# Altium schematic sheet COFFEE_MAIN.SchDoc
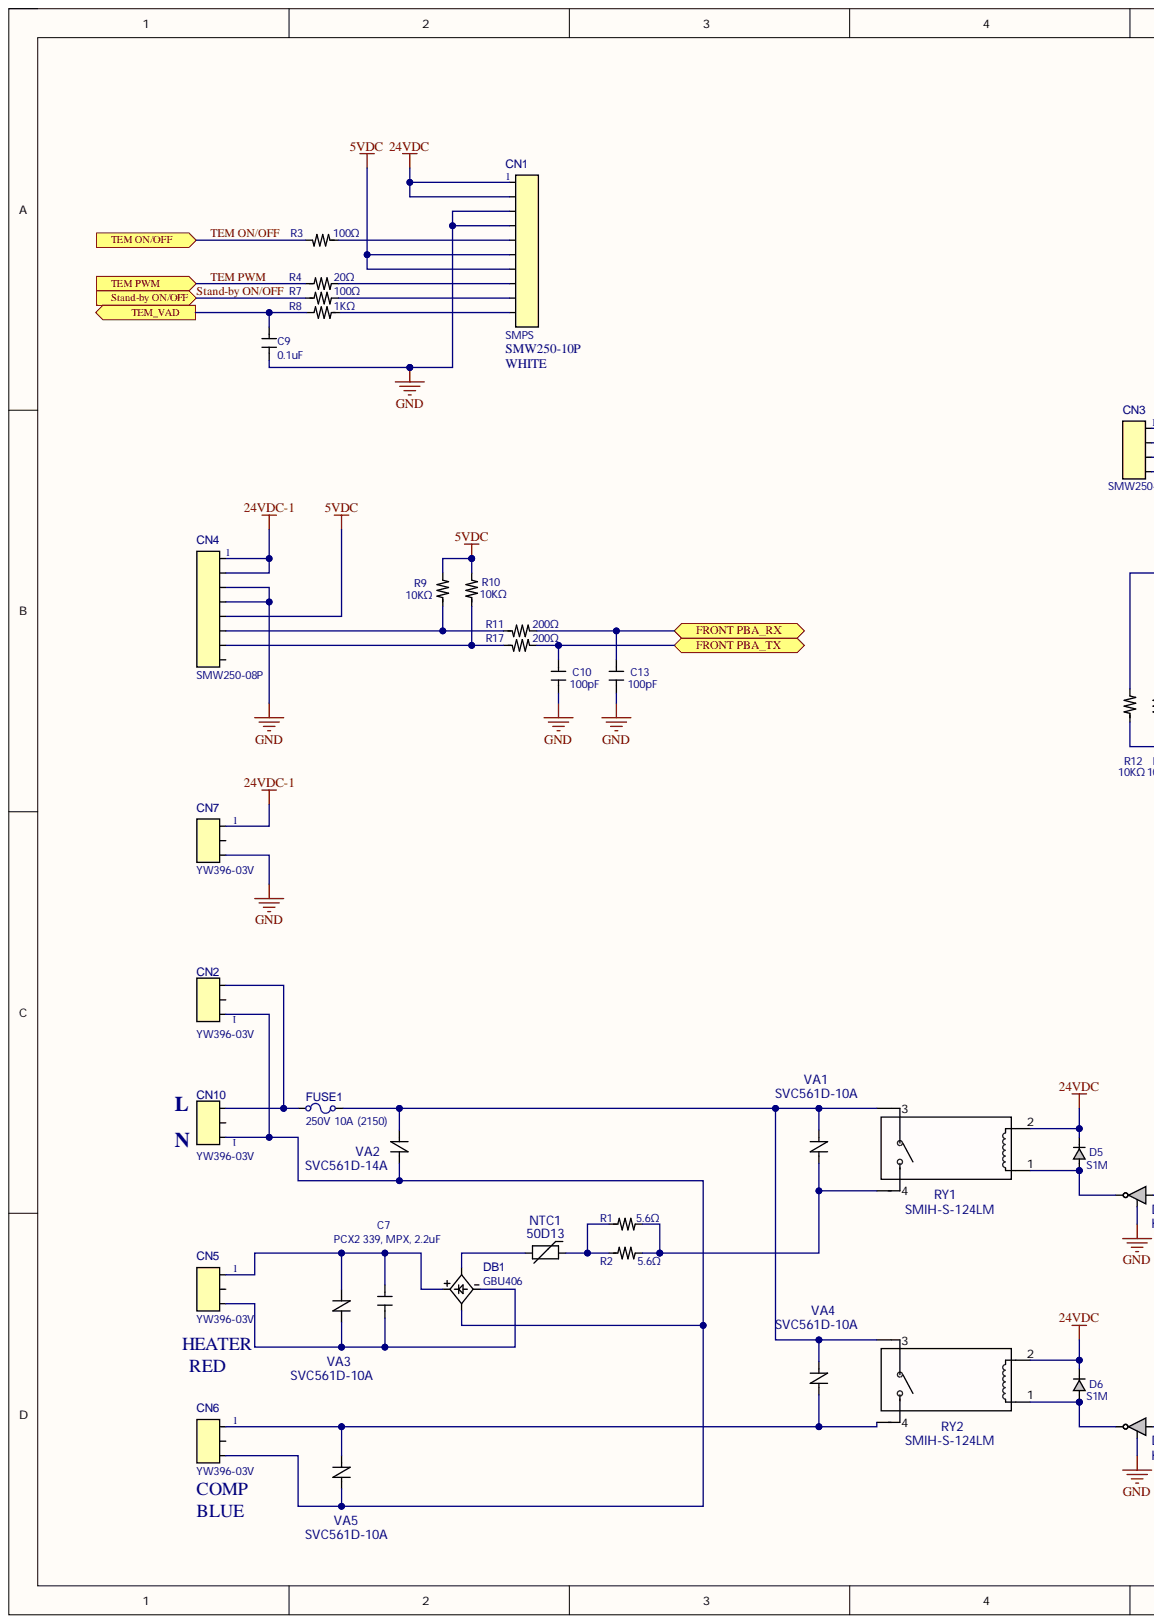
[diagram p0: COFFEE_MAIN.SchDoc, left]
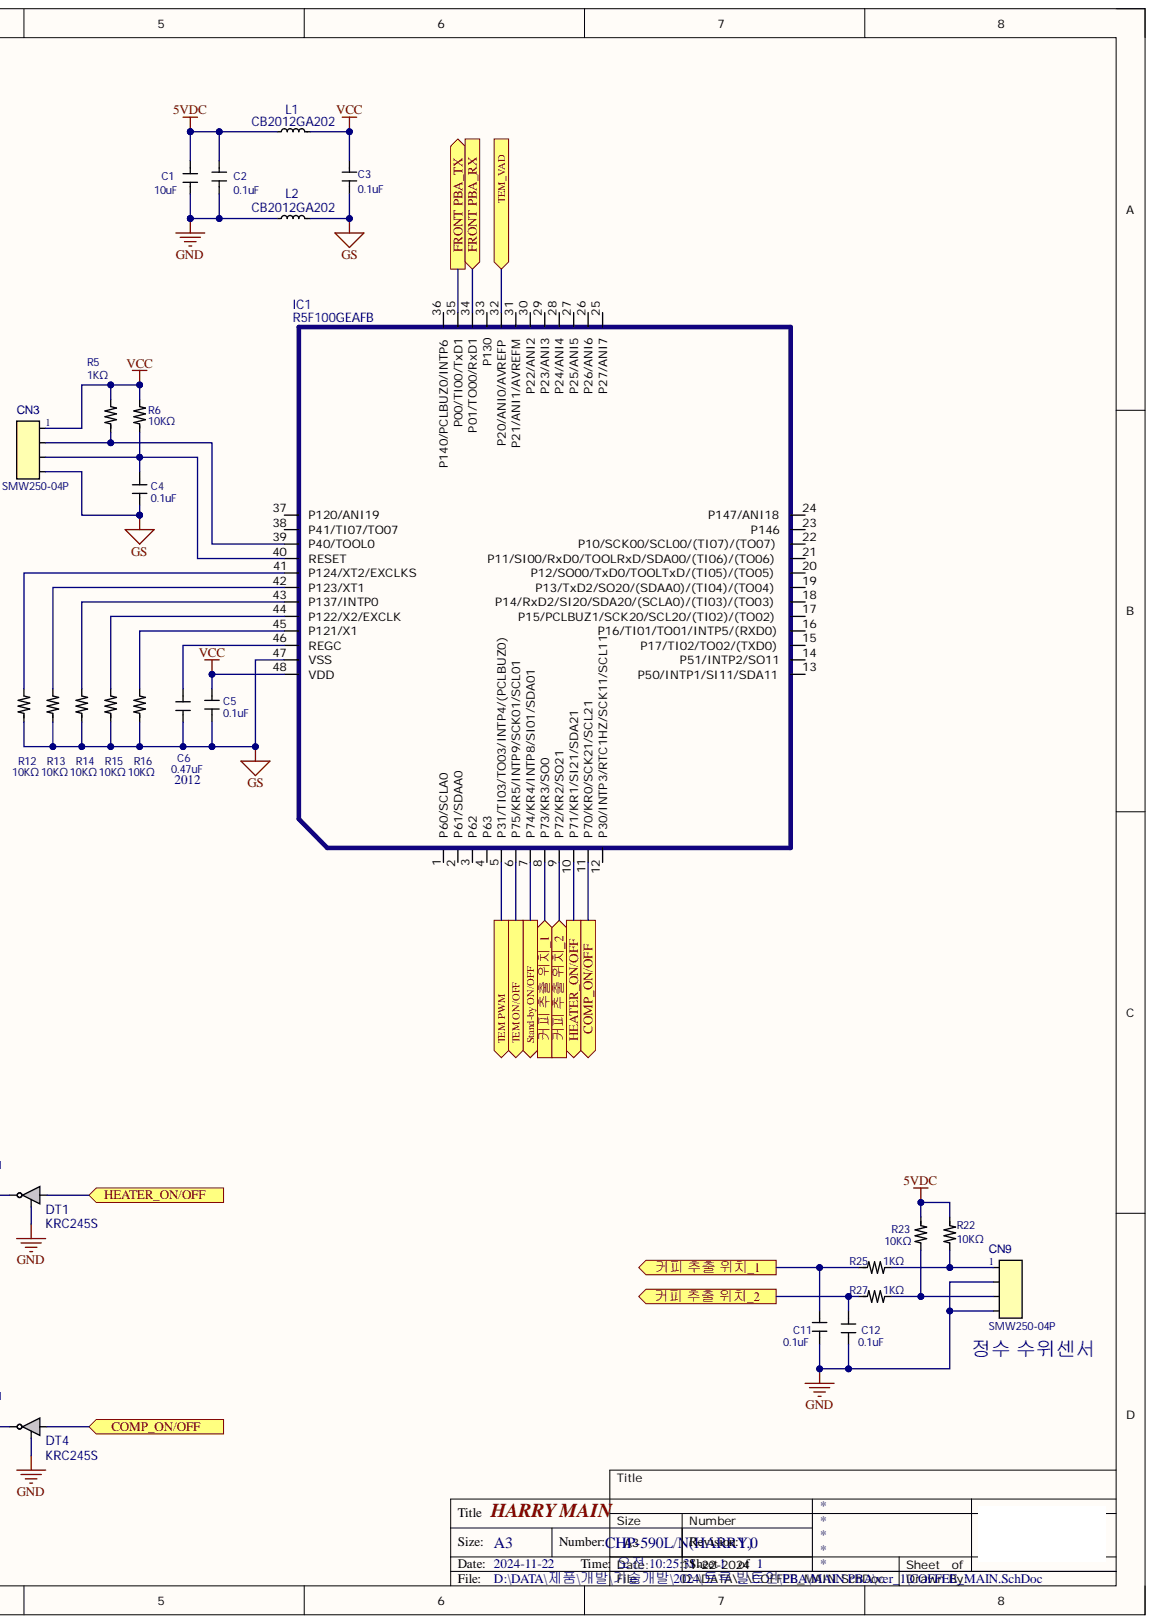
[diagram p1: COFFEE_MAIN.SchDoc, right]

## Parts
C1  10uF  [C-SMD-3216]
C2  0.1uF  [C-SMD-1608]
C3  0.1uF  [C-SMD-1608]
C4  0.1uF  [C-SMD-1608]
C5  0.1uF  [C-SMD-1608]
C6  0.47uF  [C-SMD-2012]
C7  PCX2 339, MPX, 2.2uF  [C-BOX-27_0P-312818]
C9  0.1uF  [C-SMD-1608]
C10  100pF  [C-SMD-1608]
C11  0.1uF  [C-SMD-1608]
C12  0.1uF  [C-SMD-1608]
C13  100pF  [C-SMD-1608]
CN1  SMPS  14.Color: WHITE  21.Value: SMW250-10P  [CN-SMW250-10-10P-2_5P-274058-070H]
CN2  YW396-03V  [CN-YW396-03V-03P-3_96P-118081-111H]
CN3  SMW250-04P  [CN-SMW250-04-04P-2_5P-124058-070H]
CN4  SMW250-08P  [CN-SMW250-08-08P-2_5P-224058-070H]
CN5  YW396-03V  [CN-YW396-03V-03P-3_96P-118081-111H]
CN6  YW396-03V  [CN-YW396-03V-03P-3_96P-118081-111H]
CN7  YW396-03V  [CN-YW396-03V-03P-3_96P-118081-111H]
CN9  SMW250-04P  [CN-SMW250-04-04P-2_5P-124058-070H]
CN10  YW396-03V  [CN-YW396-03V-03P-3_96P-118081-111H]
D5  S1M  [D_DO-214AC]
D6  S1M  [D_DO-214AC]
DB1  GBU406  [GBU406-Footprint-1]
DT1  KRC245S  [TR-SOT-23_BCE-3P-1_0P-031027-013H]
DT4  KRC245S  [TR-SOT-23_BCE-3P-1_0P-031027-013H]
FUSE1  250V 10A (2150)  [FUSE_20MM]
IC1  R5F100GEAFB  [IC-LQFP48P-0_48P]
L1  CB2012GA202  [L_2012SMD]
L2  CB2012GA202  [L_2012SMD]
NTC1  50D13  [TH_11PI_7.5P]
R1  5.6Ω  [R-AX-15_0P-120056-56H]
R2  5.6Ω  [R-AX-15_0P-120056-56H]
R3  100Ω  [R-SMD-1608-045H]
R4  20Ω  [R-SMD-1608-045H]
R5  1KΩ  [R-SMD-1608-045H]
R6  10KΩ  [R-SMD-1608-045H]
R7  100Ω  [R-SMD-1608-045H]
R8  1KΩ  [R-SMD-1608-045H]
R9  10KΩ  [R-SMD-1608-045H]
R10  10KΩ  [R-SMD-1608-045H]
R11  200Ω  [R-SMD-1608-045H]
R12  10KΩ  [R-SMD-1608-045H]
R13  10KΩ  [R-SMD-1608-045H]
R14  10KΩ  [R-SMD-1608-045H]
R15  10KΩ  [R-SMD-1608-045H]
R16  10KΩ  [R-SMD-1608-045H]
R17  200Ω  [R-SMD-1608-045H]
R22  10KΩ  [R-SMD-1608-045H]
R23  10KΩ  [R-SMD-1608-045H]
R25  1KΩ  [R-SMD-1608-045H]
R27  1KΩ  [R-SMD-1608-045H]
RY1  SMIH-S-124LM  [OMIH-SS-124LM]
RY2  SMIH-S-124LM  [OMIH-SS-124LM]
VA1  SVC561D-10A  [ZNR_D10]
VA2  SVC561D-14A  [ZNR_D14]
VA3  SVC561D-10A  [ZNR_D10]
VA4  SVC561D-10A  [ZNR_D10]
VA5  SVC561D-10A  [ZNR_D10]

## Ports
COMP_ON/OFF, FRONT PBA_RX, FRONT PBA_TX, HEATER_ON/OFF, Stand-by ON/OFF, TEM ON/OFF, TEM PWM, TEM_VAD, 커피 추출 위치_1, 커피 추출 위치_2

## Nets
5VDC: C1.2 C2.1 CN1.6 CN1.7 CN4.5 L1.1 R9.2 R10.2 R22.1 R23.1
24VDC: CN1.1 CN1.2 D5.C D6.C RY1.2 RY2.2
24VDC-1: CN4.1 CN4.2 CN7.1
GND: C1.1 C2.2 C9.2 C10.2 C11.2 C12.1 C13.2 CN1.3 CN1.4 CN4.3 CN4.4 CN7.3 CN9.2 CN9.4 DT1.E DT4.E L2.1
GS: C3.2 C4.1 C5.2 C6.1 CN3.4 IC1.47(VSS) L2.2 R12.1 R13.1 R14.1 R15.1 R16.1
VCC: C3.1 C5.1 CN3.1 IC1.48(VDD) L1.2 R5.2 R6.2
COMP_ON/OFF: DT4.B IC1.11(P70/KR0/SCK21/SCL21) ; port COMP_ON/OFF
FRONT PBA_RX: C13.1 IC1.34(P01/TO00/RxD1) R11.2 ; port FRONT PBA_RX
FRONT PBA_TX: C10.1 IC1.35(P00/TI00/TxD1) R17.2 ; port FRONT PBA_TX
HEATER_ON/OFF: DT1.B IC1.10(P71/KR1/SI21/SDA21) ; port HEATER_ON/OFF
Stand-by ON/OFF: IC1.7(P74/KR4/INTP8/SI01/SDA01) R7.1 ; port Stand-by ON/OFF
TEM ON/OFF: IC1.6(P75/KR5/INTP9/SCK01/SCL01) R3.2 ; port TEM ON/OFF
TEM PWM: IC1.5(P31/TI03/TO03/INTP4/(PCLBUZ0)) R4.1 ; port TEM PWM
TEM_VAD: C9.1 IC1.32(P20/ANI0/AVREFP) R8.2 ; port TEM_VAD
커피 추출 위치_1: C11.1 IC1.8(P73/KR3/SO0) R25.1 ; port 커피 추출 위치_1
커피 추출 위치_2: C12.2 IC1.9(P72/KR2/SO21) R27.1 ; port 커피 추출 위치_2
NetC4_2: C4.2 CN3.3 IC1.40(RESET) R6.1
NetC6_2: C6.2 IC1.46(REGC)
NetC7_1: C7.1 CN5.1 DB1.1 VA3.2
NetC7_2: C7.2 CN5.3 DB1.4 VA3.1
NetCN1_5: CN1.5 R3.1
NetCN1_8: CN1.8 R4.2
NetCN1_9: CN1.9 R7.2
NetCN1_10: CN1.10 R8.1
NetCN2_1: CN2.1 CN6.3 CN10.1 DB1.3 VA2.2 VA5.1
NetCN2_3: CN2.3 CN10.3 FUSE1.1
NetCN3_2: CN3.2 IC1.39(P40/TOOL0) R5.1
NetCN4_6: CN4.6 R9.1 R11.1
NetCN4_7: CN4.7 R10.1 R17.1
NetCN6_1: CN6.1 RY2.4 VA4.1 VA5.2
NetCN9_1: CN9.1 R22.2 R25.2
NetCN9_3: CN9.3 R23.2 R27.2
NetD5_A: D5.A DT1.C RY1.1
NetD6_A: D6.A DT4.C RY2.1
NetDB1_2: DB1.2 NTC1.1
NetFUSE1_2: FUSE1.2 RY1.3 RY2.3 VA1.2 VA2.1 VA4.2
NetIC1_41: IC1.41(P124/XT2/EXCLKS) R12.2
NetIC1_42: IC1.42(P123/XT1) R13.2
NetIC1_43: IC1.43(P137/INTP0) R14.2
NetIC1_44: IC1.44(P122/X2/EXCLK) R15.2
NetIC1_45: IC1.45(P121/X1) R16.2
NetNTC1_2: NTC1.2 R1.1 R2.1
NetR1_2: R1.2 R2.2 RY1.4 VA1.1

Not connected: CN2 2; CN4 8; CN5 2; CN6 2; CN7 2; CN10 2; IC1 1(P60/SCLA0) 2(P61/SDAA0) 3(P62) 4(P63) 12(P30/INTP3/RTC1HZ/SCK11/SCL11) 13(P50/INTP1/SI11/SDA11) 14(P51/INTP2/SO11) 15(P17/TI02/TO02/(TXD0)) 16(P16/TI01/TO01/INTP5/(RXD0)) 17(P15/PCLBUZ1/SCK20/SCL20/(TI02)/(TO02)) 18(P14/RxD2/SI20/SDA20/(SCLA0)/(TI03)/(TO03)) 19(P13/TxD2/SO20/(SDAA0)/(TI04)/(TO04)) 20(P12/SO00/TxD0/TOOLTxD/(TI05)/(TO05)) 21(P11/SI00/RxD0/TOOLRxD/SDA00/(TI06)/(TO06)) 22(P10/SCK00/SCL00/(TI07)/(TO07)) 23(P146) 24(P147/ANI18) 25(P27/ANI7) 26(P26/ANI6) 27(P25/ANI5) 28(P24/ANI4) 29(P23/ANI3) 30(P22/ANI2) 31(P21/ANI1/AVREFM) 33(P130) 36(P140/PCLBUZ0/INTP6) 37(P120/ANI19) 38(P41/TI07/TO07)

## Library data of the parts
C1 = CMP-00585-2: 10uF,25V,3216,125℃,+10, -10%,,Reel,; 02.Part Number: 8026573; 01.No: 26432; 03.부품분류: CAPACITOR, MLCC; 13.PKG: 3216; 15.C Tolerance: 10; 11.Capacitance: 10uF; 12.Voltage: 25V; 14.Temperature: 125℃; 16.Pitch: -10%; 21.Value: 10uF; 22.등록일: 2014-02-28; Component Kind: Standard; Library Name: Coway 365
C2 C3 C5 C9 = CMP-00596-2: 0.1uF,50V,1608,125℃,+10, -10%,,Reel,; 02.Part Number: 8026584; 01.No: 26443; 03.부품분류: CAPACITOR, MLCC; 13.PKG: 1608; 15.C Tolerance: 10; 11.Capacitance: 0.1uF; 12.Voltage: 50V; 14.Temperature: 125℃; 16.Pitch: -10%; 21.Value: 0.1uF; 22.등록일: 2014-02-28; Component Kind: Standard; Library Name: <Coway> Concord
C4 C11 C12 = CMP-00566-2: 0.1uF,50V,1608,125℃,+10, -10%,,Reel,; 02.Part Number: 8026554; 01.No: 26413; 03.부품분류: CAPACITOR, MLCC; 13.PKG: 1608; 15.C Tolerance: 10; 11.Capacitance: 0.1uF; 12.Voltage: 50V; 14.Temperature: 125℃; 16.Pitch: -10%; 21.Value: 0.1uF; 22.등록일: 2014-02-28; Component Kind: Standard
  C4: Library Name: <Coway> Concord
  C11 C12: Library Name: Coway 365
C6 = CMP-00523-2: 0.47uF,50V,2012,125℃,+10,-10%,,Reel,; 02.Part Number: 8026191; 01.No: 26054; 03.부품분류: CAPACITOR, MLCC; 13.PKG: 2012; 15.C Tolerance: 10; 11.Capacitance: 0.47uF; 12.Voltage: 50V; 14.Temperature: 125℃; 16.Pitch: -10%; 21.Value: 0.47uF; 22.등록일: 2014-02-28; Component Kind: Standard; Library Name: <Coway> Concord
C7 = CMP-00647-2: 2.2uF,275V,31x28x18mm,100℃,,27.5mm,,; 02.Part Number: 8026223; 01.No: 58; 03.부품분류: CAPACITOR, FILM; 11.Capacitance: 2.2uF; 12.Voltage: 275V; 13.Dimension: 31x28x18mm; 14.Temperature: 100℃; 16.Pitch: 27.5mm; 21.Value: 2.2uF; 22.등록일: 2015-07-28; Component Kind: Standard; Library Name: Coway 365
C10 C13 = CMP-00577-2: 100pF,50V,1608,125℃,+5, -5%,,Reel,; 02.Part Number: 8026565; 01.No: 26424; 03.부품분류: CAPACITOR, MLCC; 13.PKG: 1608; 15.C Tolerance: 5; 11.Capacitance: 100pF; 12.Voltage: 50V; 14.Temperature: 125℃; 16.Pitch: -5%; 21.Value: 100pF; 22.등록일: 2014-02-28; Component Kind: Standard; Library Name: <Coway> Concord
CN1 = CMP-01012-2: straight,10,2.5,,250,3,; 02.Part Number: 8027203; 01.No: 26944; 03.부품분류: CONNECTOR, DIP; 12.No. of Pin: 10; 13.Pitch: 2.5; 15.I Max: 250; 16.Height: 3; 11Type: straight; 22.등록일: 2014-02-28; Component Kind: Standard; Library Name: <Coway> Concord
CN2 CN5-CN7 CN10 = CMP-00904-3: straight,3,3.96,,250,7.5,; 02.Part Number: 8027095; 01.No: 26836; 03.부품분류: CONNECTOR, DIP; 12.No. of Pin: 3; 13.Pitch: 3.96; 15.I Max: 250; 16.Height: 7.5; 11Type: straight; 14.Color: -; 21.Value: YW396-03V; 22.등록일: 2014-02-28; Component Kind: Standard; Library Name: Coway 365
CN3 CN9 = CMP-01006-3: straight,4,2.5,,250,3,; 02.Part Number: 8027197; 01.No: 26938; 03.부품분류: CONNECTOR, DIP; 12.No. of Pin: 4; 13.Pitch: 2.5; 15.I Max: 250; 16.Height: 3; 11Type: straight; 14.Color: -; 21.Value: SMW250-04P; 22.등록일: 2014-02-28; Component Kind: Standard; Library Name: Coway 365
CN4 = CMP-01010-2: straight,8,2.5,,250,3,; 02.Part Number: 8027201; 01.No: 26942; 03.부품분류: CONNECTOR, DIP; 12.No. of Pin: 8; 13.Pitch: 2.5; 15.I Max: 250; 16.Height: 3; 11Type: straight; 14.Color: -; 21.Value: SMW250-08P; 22.등록일: 2014-02-28; Component Kind: Standard; Library Name: Coway 365
D5 D6 = CMP-00066-2: 1000V,1A,1.1V,DO-214AC,,; 02.Part Number: 8025325; 01.No: 78; 03.부품분류: DIODE, RECTIFIER; 11.Vr: 1000V; 12.IF: 1A; 13.Power: 1.1V; 14.PKG: DO-214AC; 21.Value: S1M; 22.등록일: 2015-01-29; Component Kind: Standard; Library Name: <Coway> Concord
DB1 = CMP-00037-3: 800V,4A,1V,,,; 02.Part Number: 8025405; 01.No: 25557; 03.부품분류: DIODE, BRIDGE; 11.Vr: 800V; 12.IF: 4A; 13.No. of Pin: 1V; 14.Dimension: -; 21.Value: GBU406; 22.등록일: 2014-02-28; Component Kind: Standard; Library Name: Coway 365
DT1 DT4 = CMP-27504-1: NPN,50V,0.8A,SOT-23,0.2W,56,1K/10K,; 02.Part Number: 8025514; 01.No: 25664; 03.부품분류: TR, DIGITAL; 16.hfe: 56; 11.Channel: NPN; 12.Max VCE: 50V; 13.Max IC: 0.8A; 14.PKG: SOT-23; 15.Power: 0.2W; 17.R2/R1: 1K/10K; 21.Value: KRC245S; 22.등록일: 2014-02-28; Component Kind: Standard; Library Name: <Coway> Concord
FUSE1 = CMP-01936-2: 250,10,Time_Lag,,20±0.5,; 02.Part Number: 8027031; 01.No: 26796; 03.부품분류: PROTECTION DEVICE, FUSE; 11.Rated Voltage: 250; 12.Rated Current: 10; 13.MELTING TYPE: Time_Lag; 14.Meterial: -; 15.Dimension: 20±0.5; 21.Value: 250V 10A (2150); 22.등록일: 2014-02-28; Component Kind: Standard; Library Name: Coway 365
IC1 = CMP-00644-2: 48P,10P,44P,LFQFP,bit,bits,℃,; 02.Part Number: 8028492; 01.No: 5; 03.부품분류: IC, MICOM; 23.신뢰성: ok; 11.No. of Pin: 48P; 12.No. of ADC: 10P; 13.No. of IO PIN: 44P; 14.PKG: LFQFP; 15.ROM Size: bit; 16.RAM Size: bits; 17.t Max: ℃; 21.Value: R5F100GEAFB; 22.등록일: 2018-02-13; Component Kind: Standard; Library Name: <Coway> Concord
L1 L2 = CMP-01487-1: ,,,,,,; 02.Part Number: 8026661; 01.No: 26502; 03.부품분류: INDUCTOR, AXIAL; 11.Inductance: -; 12.Tolerance: -; 13.Current: -; 14.Dimension: -; 22.등록일: 2014-02-28; Component Kind: Standard; Library Name: <Coway> Concord
NTC1 = CMP-01434-2: 10,≤20,3000±5%,11,,7.5±1.0,; 02.Part Number: 8027081; 01.No: 148; 03.부품분류: PROTECTION DEVICE, THERMISTOR; 11.Resistance: 10; 14.PI: 11; 21.Value: 10D11; 12.Tolerance: ≤20; 13.B Value: 3000±5%; 16.Dimension: 7.5±1.0; 22.등록일: 2014-08-01; Component Kind: Standard; Library Name: Coway 365
R1 R2 = CMP-19775-2: 220KΩ,2W,F,11.0x4.0mm,,; 02.Part Number: 8022703; 01.No: 22863; 03.부품분류: RESISTOR, METAL; 11.Resistance: 220KΩ; 12.Power: 2W; 13.Tolerance: F; 14.Dimension: 11.0x4.0mm; 21.Value: 220KΩ; 22.등록일: 2014-02-28; Component Kind: Standard; Library Name: Coway 365
R3 R7 = CMP-25412-2: 100Ω,1/10W,J,1608,,; 02.Part Number: 8003111; 01.No: 3271; 03.부품분류: RESISTOR, SMD; 14.PKG: 1608; 11.Resistance: 100Ω; 12.Power: 1/10W; 13.Tolerance: J; 21.Value: 100Ω; 22.등록일: 2014-02-28; Component Kind: Standard; Library Name: <Coway> Concord
R4 = CMP-22332-2: 20Ω,1/10W,J,1608,,; 02.Part Number: 8000031; 01.No: 191; 03.부품분류: RESISTOR, SMD; 14.PKG: 1608; 11.Resistance: 20Ω; 12.Power: 1/10W; 13.Tolerance: J; 21.Value: 20Ω; 22.등록일: 2014-02-28; Component Kind: Standard; Library Name: <Coway> Concord
R5 R6 R8-R10 R12-R16 R25 R27 = CMP-25460-2: 10KΩ,1/10W,J,1608,,; 02.Part Number: 8003159; 01.No: 3319; 03.부품분류: RESISTOR, SMD; 14.PKG: 1608; 11.Resistance: 10KΩ; 12.Power: 1/10W; 13.Tolerance: J; 21.Value: 10KΩ; 22.등록일: 2014-02-28; Component Kind: Standard; Library Name: Coway 365
R11 R17 = CMP-23386-2: 200Ω,1/10W,J,1608,,; 02.Part Number: 8001085; 01.No: 1245; 03.부품분류: RESISTOR, SMD; 14.PKG: 1608; 11.Resistance: 200Ω; 12.Power: 1/10W; 13.Tolerance: J; 21.Value: 200Ω; 22.등록일: 2014-02-28; Component Kind: Standard; Library Name: <Coway> Concord
R22 R23 = CMP-24436-2: 10KΩ,1/10W,J,1608,,; 02.Part Number: 8002135; 01.No: 2295; 03.부품분류: RESISTOR, SMD; 14.PKG: 1608; 11.Resistance: 10KΩ; 12.Power: 1/10W; 13.Tolerance: J; 21.Value: 10KΩ; 22.등록일: 2014-02-28; Component Kind: Standard; Library Name: <Coway> Concord
RY1 RY2 = CMP-02188-2: ,,,,,,; 02.Part Number: 8026758; 01.No: 26550; 03.부품분류: RELAY, A CONTACT; 23.신뢰성: ok; 11.V DC: -; 12.V AC: -; 13.Contact Allowable Current: -; 14.Coil Resistance: -; 21.Value: SMIH-S-124LM; 22.등록일: 2014-02-28; Component Kind: Standard; Library Name: <Coway> Concord
VA1 VA3-VA5 = CMP-01440-2: 560,2500,10,10mm,14.0x7.5mm; 02.Part Number: 8026804; 01.No: 26571; 03.부품분류: PROTECTION DEVICE, VARISTOR; 11.V Max: 560; 12.I peak: 2500; 13.PI: 10; 14.Dimension: 10mm; 15.Etc: 14.0x7.5mm; 21.Value: SVC561D-10A; 22.등록일: 2014-02-28; Component Kind: Standard; Library Name: <Coway> Concord
VA2 = CMP-01441-2: 560,4500,14,10mm,17.5x7.5mm; 02.Part Number: 8026805; 01.No: 26572; 03.부품분류: PROTECTION DEVICE, VARISTOR; 11.V Max: 560; 12.I peak: 4500; 13.PI: 14; 14.Dimension: 10mm; 15.Etc: 17.5x7.5mm; 21.Value: SVC561D-14A; 22.등록일: 2014-02-28; Component Kind: Standard; Library Name: <Coway> Concord
